# Altium schematic sheet Lipol_battery_charger.SchDoc(Lipol_battery_charger)
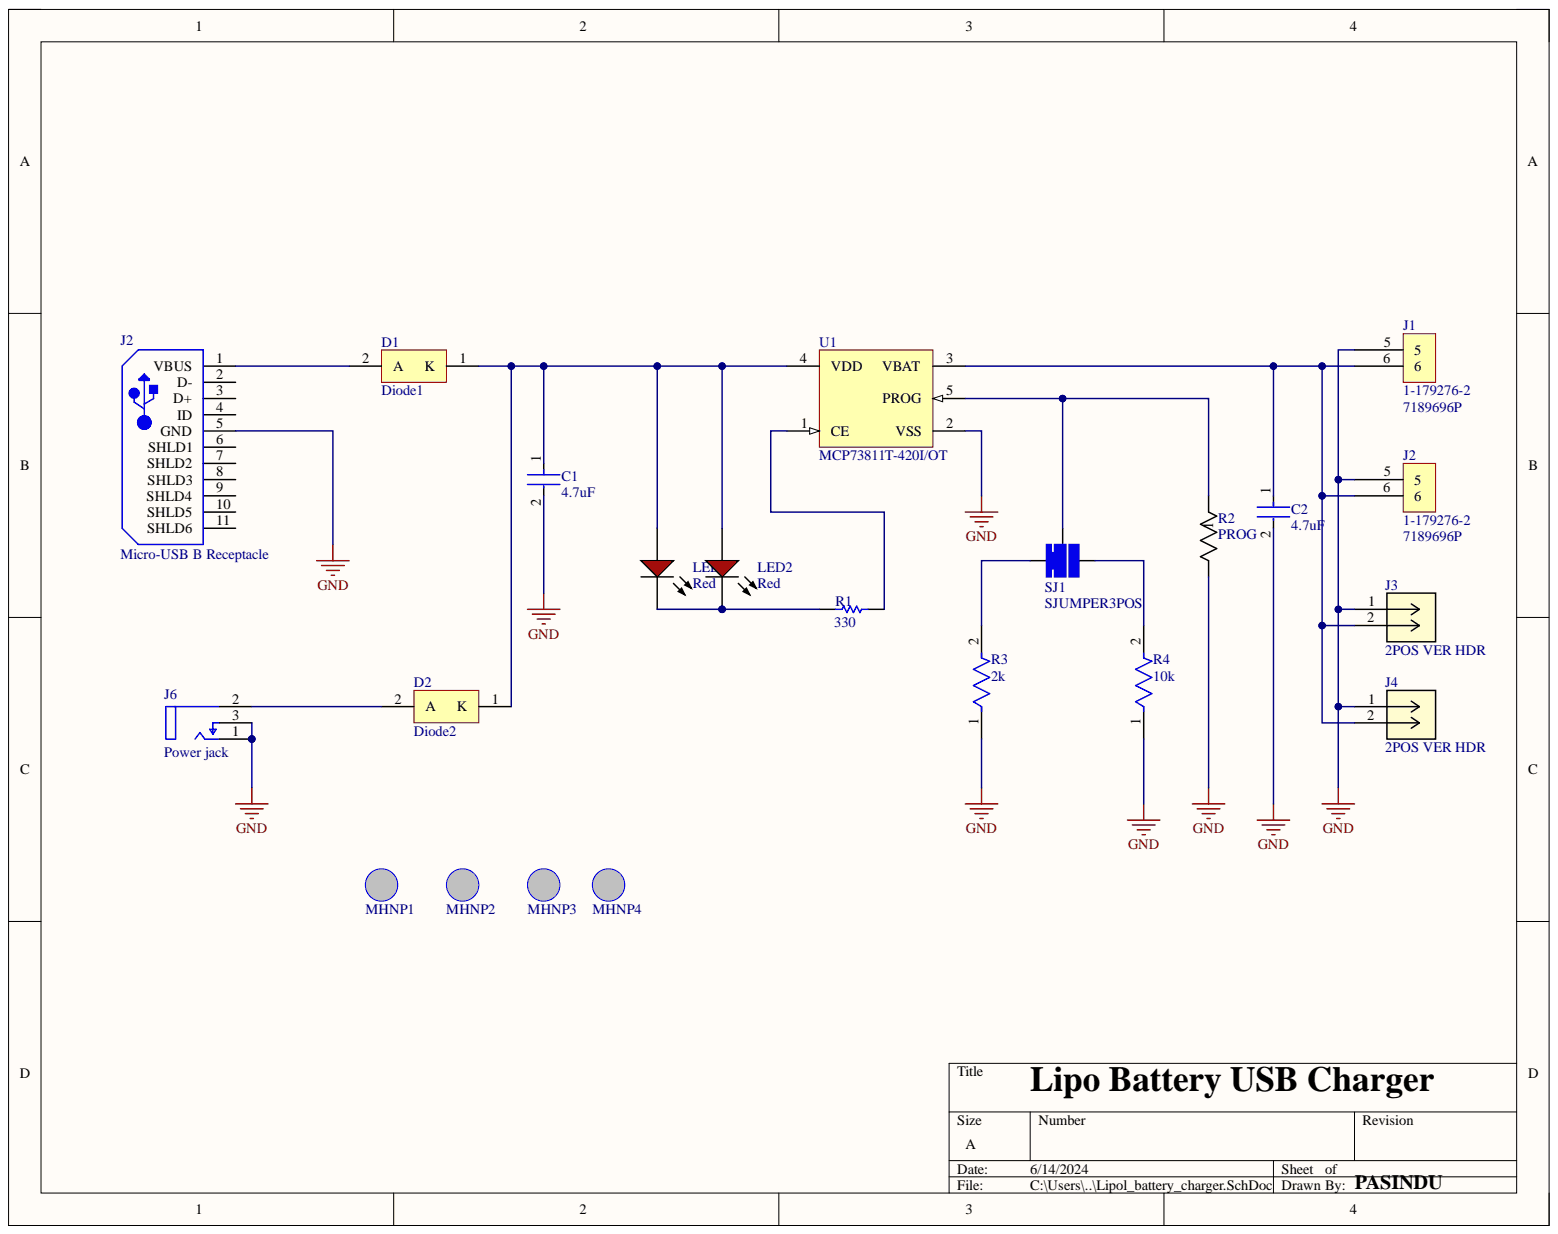
[diagram p0: Lipol_battery_charger.SchDoc]

## Parts
C1  4.7uF  [FP-CL10-IPC_C]
C2  4.7uF  [FP-CL10-IPC_C]
D1  Diode1  [ONSC-SMA-2-403D-02_V]
D2  Diode2  [ONSC-SMA-2-403D-02_V]
J1  1-179276-2  Supplier Part Number 1: 7189696P  [1-179276-2]
J2  1-179276-2  Supplier Part Number 1: 7189696P  [1-179276-2]
J2  Micro-USB B Receptacle  Gender: Receptacle  [USB-MICRO-B_V]
J3  2POS VER HDR  [CONN2_M20-999_1x2_HRW]
J4  2POS VER HDR  [CONN2_M20-999_1x2_HRW]
J6  Power jack  [FP-RASM722PTR13X-MFG]
LED1  Red  [VISH-TLMX1100_V]
LED2  Red  [VISH-TLMX1100_V]
MHNP1  Mounting hole non plated 3.2mm  [MHNP3P2MM]  (value not drawn)
MHNP2  Mounting hole non plated 3.2mm  [MHNP3P2MM]  (value not drawn)
MHNP3  Mounting hole non plated 3.2mm  [MHNP3P2MM]  (value not drawn)
MHNP4  Mounting hole non plated 3.2mm  [MHNP3P2MM]  (value not drawn)
R1  330  [RESC2013X70X40ML20T20]
R2  PROG  [RES_AC03_VIS]
R3  2k  [FP-CRCW0805-HPe3-IPC_C]
R4  10k  [FP-CRGCQ0805-IPC_C]
SJ1  SJUMPER3POS  [SJUMPER3POS-Footprint-1]
U1  MCP73811T-420I/OT  [SOT-23-OT5_L]

## Nets
GND: C1.2 C2.2 J1.5 J2.5 J3.1 J4.1 J6.1 J6.3 R2.2 R3.1 R4.1 U1.2(VSS)
NetC1_1: C1.1 D1.1 D2.1 LED1.1 LED2.1 U1.4(VDD)
NetC2_1: C2.1 J1.6 J2.6 J3.2 J4.2 U1.3(VBAT)
NetD1_2: D1.2 J2.1(VBUS)
NetD2_2: D2.2 J6.2
NetLED1_2: LED1.2 LED2.2 R1.1
NetR1_2: R1.2 U1.1(CE)
NetR2_1: R2.1 SJ1.2 U1.5(PROG)
NetR3_2: R3.2 SJ1.1
NetR4_2: R4.2 SJ1.3

Not connected: J2 2(D-) 3(D+) 4(ID) 7(SHLD2) 8(SHLD3) 9(SHLD4) 10(SHLD5) 11(SHLD6)

## Library data of the parts
C1 C2 = CMP-2000-06096-2: CAP CER 4.7UF 6.3V X7R 0603; Manufacturer: Samsung; Manufacturer Part Number: CL10B475KQ8NQNC; Material: Ceramic; Temperature Coefficient: 15%; Tolerance: 10%; Automotive: No; Capacitance: 4.7uF; Category: Cap; Component Kind: Standard; ComponentLink2Description: Datasheet; ComponentLink2URL: http://www.samsungsem.com/kr/support/product-search/mlcc/__icsFiles/afieldfile/2018/07/24/CL10B475KQ8NQNC.pdf; Device Class L1: Passive Components; Device Class L2: Capacitors; Device Class L3: Ceramic Capacitors; Digikey Description: CAP CER 4.7UF 6.3V X7R 0603; Height: 0.95mm; Ipc Land Pattern Name: CAPC16080X80; Lead Free: Yes; Library Name: Altium Content Vault; Mouser Description: C Series 0402 4.7 uF 6.3 V ±20 % Tolerance X5R SMT Multilayer Ceramic Capacitor; Octopart: https://octopart.com/cl10b475kq8nqnc-samsung-24957976; Package: CL10; RoHS: TRUE; Temperature: -55°C to +125°C; Temperature Characteristic: X7R; Temperature Range High: +125°C; Temperature Range Low: -55°C; Value: 4.7uF; Voltage Rating: 6.3V
D1 D2 = CMP-1055-00320-1: Schottky Power Rectifier, 2-Pin SMA, Pb-Free, Tape and Reel; Manufacturer: On Semiconductor; PartNumber: MBRA140T3G; Configuration: Single; IFSM Max (A): 30; Component Kind: Standard; ComponentLink1Description: Manufacturer URL; ComponentLink1URL: http://www.onsemi.com/; ComponentLink2Description: Datasheet; ComponentLink2URL: http://www.onsemi.cn/pub_link/Collateral/MBRA140T3-D.PDF; ComponentLink3Description: Package Specification; ComponentLink3URL: http://www.onsemi.com/pub_link/Collateral/403D-02.PDF; DatasheetVersion: Rev. 10; Library Name: Altium Content Vault; Mounting Technology: Surface Mount; PackageDescription: 2-Pin Small Molded Package, J-Bend Lead, Body 2.605 x 4.315 mm; PackageReference: SMA-2-403D-02; PackageVersion: Rev. G, 07/2012; Packing: Tape and Reel; RoHS: TRUE
J1 J2 = 1-179276-2: DYNAMIC 3200 HDR ASSY 2P H; Manufacture: TE connectivity; Component Kind: Standard; ComponentLink1Description: product URL; ComponentLink1URL: https://octopart.com/search?q=1-179276-2&filter%5Bfields%5D%5Bbrand.name%5D%5B%5D=TE+Connectivity; Manufacture part Number: 1-179276-2; Supplier 1: Rs; Library Name: Li_iron_battery_charger.SchLib
J2 = CMP-2000-05827-1: Micro-USB B Receptacle, Right Angle, Bottom Mount, Surface Mount, with Solder Tabs, -30 to 85 degC, 5-Pin USB, RoHS, Tape and Reel; Manufacturer: Molex; 3D Model: 105017-0001.stp; Catalog Drawings: 1050170001; Circuits: 5; Connector Type: USB-microB; Featured Product: Micro-USBConnectorsUSBConnectors; Mating Products: WM17145-ND-CABLEMICROUSBBTOSTDA1.0MWM17147-ND-CABLEMICROUSBBTOSTDA2.0MWM17146-ND-CABLEMICROUSBBTOSTDA1.5M; Online Catalog: Molex-MicroUSB; Other Names: WM1399DKR; Package Version: Rev. F, 12/2012; PCN Assembly/Origin: ResinSupplier21/Oct/2015; Voltage - Max: 30V; Category: Connectors,Interconnects; Component Kind: Standard; Datasheet URL: http://www.molex.com/elqNow/elqRedir.htm?ref=http://www.molex.com/webdocs/datasheets/pdf/en-us/1050170001_IO_CONNECTORS.pdf; Datasheet Version: 03/2016; Family: USB,DVI,HDMIConnectors; Features: Shielded,SolderRetention; Ingress Protection: -; Library Name: Altium Content Vault; Manufacturer URL: http://www.molex.com/; Mounting Technology: SurfaceMount; Mounting Type: BoardCutout,SurfaceMount,RightAngle; Number of Contacts: 5; Package Description: 5-Leads USB, Micro B, Body 7.5 x 5 mm, Pitch 0.65 mm; Package Reference: MICRO-B; Package URL: http://www.molex.com/pdm_docs/sd/1050170001_sd.pdf; Packaging: Digi-Reel®; RoHS Information: 1050170001CertofCompliance; Series: -; Specifications: -; Standard Package: 1
J3 J4 = M20-9990246: Connector Header Through Hole 2 position 0.100" (2.54mm); Mfr_Name: Harwin; Component Kind: Standard; ComponentLink1Description: New link; ComponentLink2Description: New link 1; ComponentLink2URL: https://www.digikey.com/en/products/detail/harwin-inc/M20-9990246/3728226; RefDes: RefDes; Type: Type; Library Name: Li_iron_battery_charger.SchLib
J6 = CMP-47995-000002-1: CONN PWR JACK 2.1X5.5MM SOLDER; Current Rating: 5A; Gender: Male; Shielding: Unshielded; Case/Package: SMD/SMT; Color: Black; Component Kind: Standard; Connector Type: Jack; Contact Material: Copper Alloy; Contact Plating: Tin; Housing Color: Black; Housing Material: Thermoplastic; Library Name: Altium Content Vault; Mount: Surface Mount; Package Quantity: 120; Termination: Solder
LED1 LED2 = CMP-1666-00027-1: LED, RED, 2.1 V, -40 to 100 degC, 2-Pin SMD (0603), RoHS, Tape and Reel; Capacitance: 12 pF; Resistance: 100000000000 Ohm; Tolerance: 5%; Type (Capacitors): C0G; Voltage Rating: 50 V; Case Code (Imperial): 0603; Case Code (Metric): 1608; Case/Package: 0603; Component Kind: Standard; ComponentLink1Description: Manufacturer URL; ComponentLink1URL: http://www.vishay.com/; ComponentLink2Description: Datasheet; ComponentLink2URL: http://www.vishay.com/docs/83173/tlmb1100.pdf; DatasheetVersion: Rev. 2.7, 03/2016; Height: 0.8 mm; Length: 1.6 mm; Library Name: Altium Content Vault; Max Operating Temperature: 125degC; Min Operating Temperature: -55degC; Mount: Surface Mount; Package Quantity: 4000; PackageDescription: 2-Pin Surface Mount Device, Body 1.6 x 0.8 mm; PackageReference: TLMX1100; Packaging: Tape and Reel; Pins: 2; Radiation Hardening: No; REACH SVHC: No SVHC; RoHSCompliant: True; Voltage Rating (DC): 50 V; Width: 0.8 mm
MHNP1-MHNP4 = MTHNP3P2MM: Mounting hole non plated 3.2mm drill hole; Component Kind: Standard; Library Name: Li_iron_battery_charger.SchLib
R1 = CMP-2001-00533-1: Manufacturer: Panasonic; Part Number: ERJ6ENF3301V; Component Kind: Standard; Library Name: Altium Content Vault
R2 = CMP-00030-39745976-1: AC03000001009JAC00 VISHAY AC03000001009JAC00 Through Hole Resistor, 10 ohm, Axial Leaded, 3 W, 5%, AC Series; Lead Diameter: 800µm; Max Power Dissipation: 3W; Resistance: 10Ω; Temperature Coefficient: -80ppm/°C; Voltage Rating: 5V; Case/Package: Conformal; Component Kind: Standard; Composition: Wirewound; Contact Plating: Tin; Diameter: 4.8mm; Features: Flame Retardant Coating; Length: 13mm; Library Name: Altium Content Vault; Max Operating Temperature: 275°C; Military Standard: Not; Min Operating Temperature: 0°C; Mount: Through Hole; NexarPartId: 39745976; Number of Pins: 2; Number of Terminations: 2; Packaging: Cut Tape (CT); Power Rating: 3W; Radiation Hardening: No; REACH SVHC: Unknown; RoHS: Compliant; Schedule B: 8533210080, 8533210080; Termination: Axial; Tolerance: 5%; Voltage Rating (DC): 5V; Width: 4.8006mm
R3 = CMP-2001-04188-2: RES Thick Film, 2kΩ, 1%, 0.5W, 100ppm/°C, 0805; Power Rating: 330mW; Resistance: 2kR; Voltage Rating: 150V; Case Code (Imperial): 0805; Case Code (Metric): 2012; Case/Package: 0805; Component Kind: Standard; Composition: Thick Film; Contact Plating: Tin; Depth: 1.25mm; Height: 0.5mm; Lead Free: Lead Free; Length: 2mm; Library Name: Altium Content Vault; Max Operating Temperature: 155°C; Military Standard: Not; Min Operating Temperature: -55°C; Mount: Surface Mount; Package Quantity: 5000; Packaging: Tape and Reel; Radiation Hardening: No; Temperature Coefficient: 100ppm/°C; Termination: SMD/SMT; Tolerance: 1%; Weight: 0.000194oz; Width: 1.2mm
R4 = CMP-03211-002989-1: CRGCQ 0805 10K 5%; Manufacturer: TE Connectivity; Manufacturer Part Number: CRGCQ0805J10K; Automotive Grade: Grade 914; Power Rating: 0.125W; Resistance: 10KΩ; Temperature Coefficient: ±100ppm/°C; Tolerance: 0.05; Value: 10KΩ; Voltage Rating: 150V; Ambient Temperature Range High: +70°C; Automotive: Yes; Category: Res; Component Kind: Standard; ComponentLink2Description: Datasheet; ComponentLink2URL: https://www.te.com/commerce/DocumentDelivery/DDEController?Action=showdoc&DocId=Data+Sheet%7F1773204-3%7F1%7Fpdf%7FEnglish%7FENG_DS_1773204-3_1.pdf%7F4-2176341-923; Composition: Thick Film; Device Class L1: Passive Components; Device Class L2: Resistors; Device Class L3: Chip SMD Resistors; Digikey Description: CRGCQ 0805 10K 5%; Height: 0.65mm; Ipc Land Pattern Name: RESC200125X55; Lead Free: Yes; Library Name: Altium Content Vault; Mouser Description: Res Thick Film 0805 10K Ohm 5% 0.125W(1/8W) ±100ppm/C Pad SMD Automotive T/R; Octopart: https://octopart.com/crgcq0805j10k-te+connectivity-91018201; Package: CRGCQ0805; RoHS: TRUE; Series: CRGCQ; Temperature: -55°C to +155°C; Temperature Range High: +155°C; Temperature Range Low: -55°C
SJ1 = CMP-001-0000-1: Solder jumper 3 pads and pin; Component Kind: Standard; pin: 3; Library Name: Nimsarapasindu231 Nimsarapasindu231
U1 = CMP-0133-00139-1: Simple, Miniature Single-Cell, Fully Integrated Li-Ion/Li-Polymer Charge Management Controller, 4.2V, 5-Pin SOT-23, Industrial Temperature, Tape and Reel; Code_IPC: SOT23-5; Component Kind: Standard; DatasheetVersion: revB, Sep-2007; PackageDescription: 5-Lead Plastic Small Outline Transistor (OT) [SOT-23]; PackageReference: SOT-23-OT5; PackageVersion: revBB, Aug-2009; Library Name: Li_iron_battery_charger.SchLib
